# Altium schematic sheet Main.SchDoc
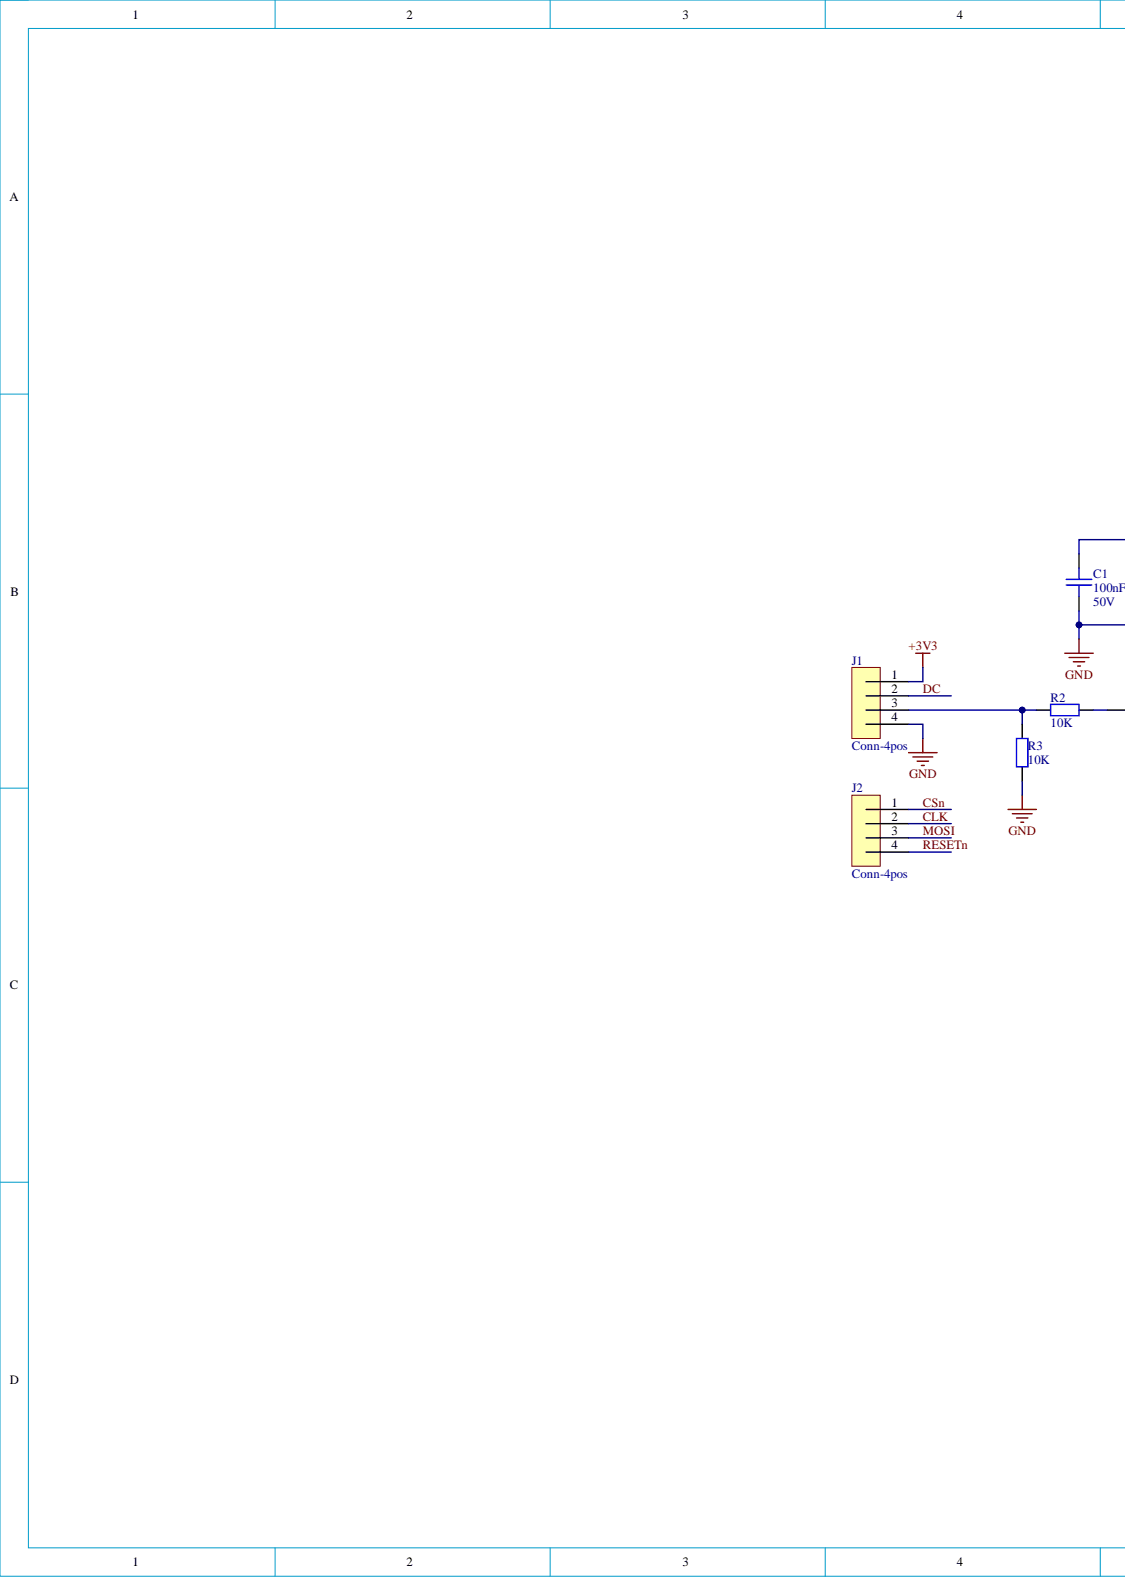
[diagram p0: Main.SchDoc, left]
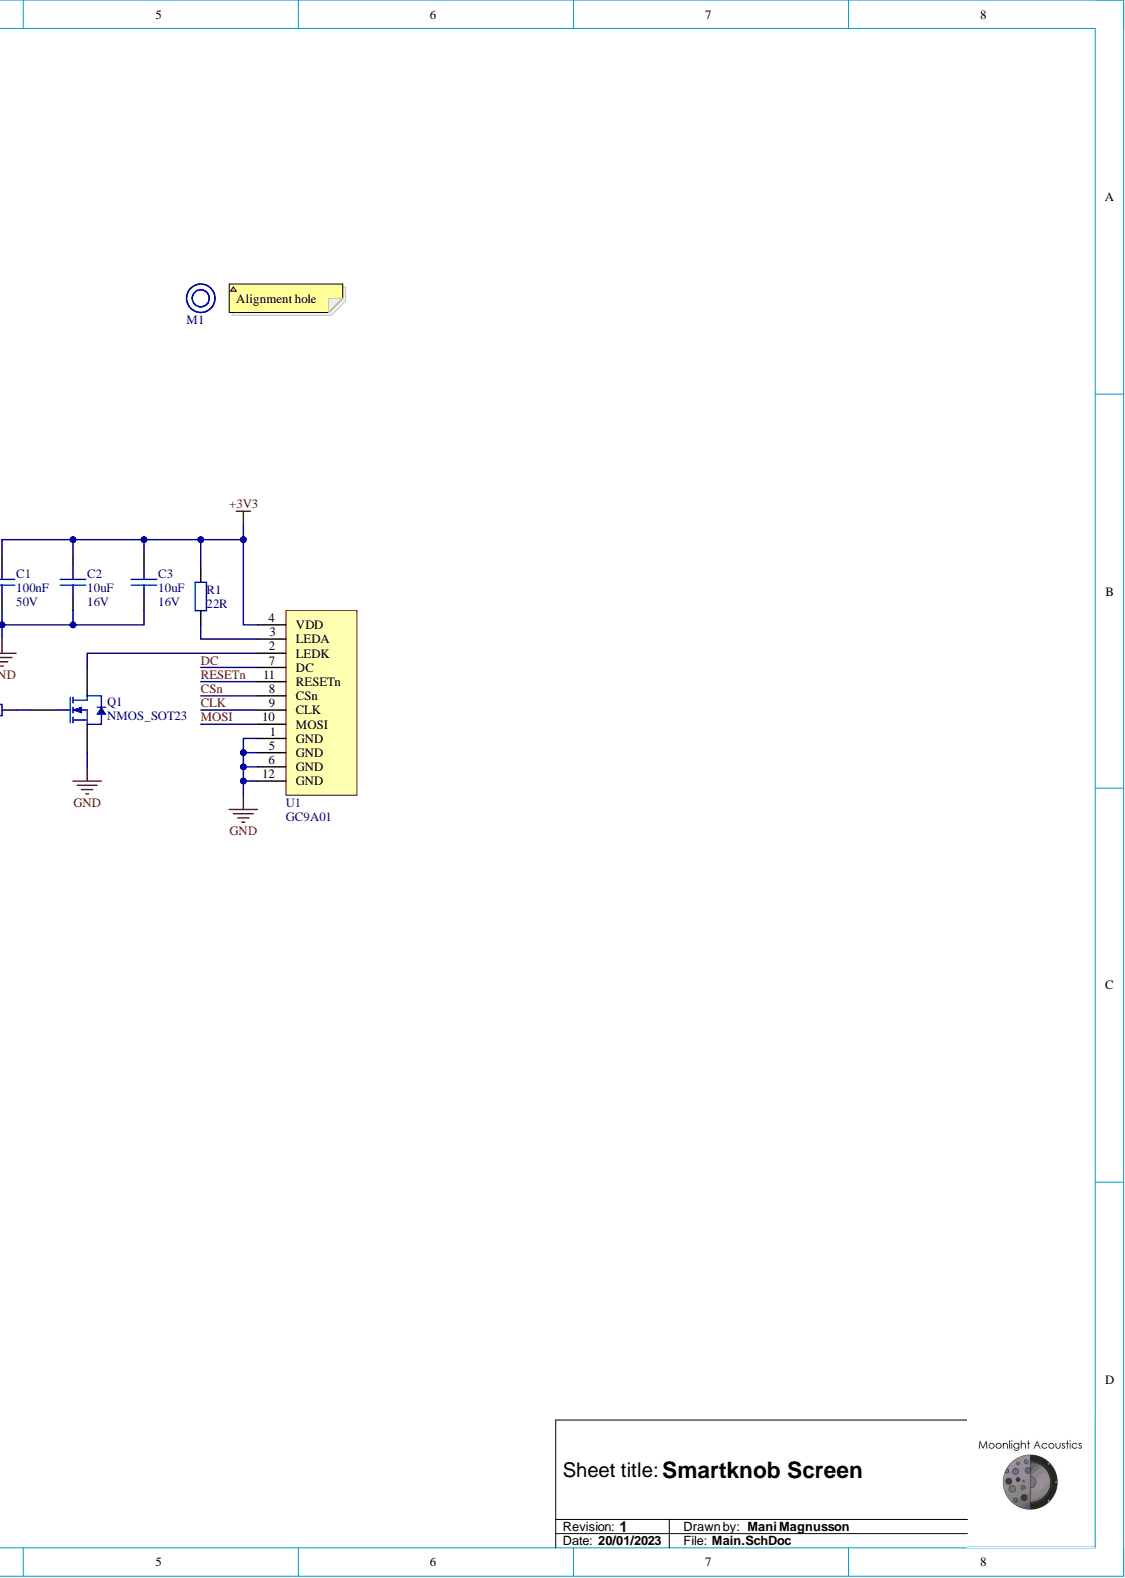
[diagram p1: Main.SchDoc, right]

## Parts
C1  value 100nF  Voltage: 50V  [C0603]  (hidden comment: CAP)
C2  value 10uF  Voltage: 16V  [C0805]  (hidden comment: CAP)
C3  value 10uF  Voltage: 16V  [C0805]  (hidden comment: CAP)
J1  Conn-4pos  [2mm_pads_4]
J2  Conn-4pos  [2mm_pads_4]
M1  Mounting_Hole_Unplated  [M1.6_Close_Unplated]  (value not drawn)
Q1  NMOS_SOT23  [SOT23]
R1  value 22R  [R0603]  (hidden comment: RES)
R2  value 10K  [R0603]  (hidden comment: RES)
R3  value 10K  [R0603]  (hidden comment: RES)
U1  GC9A01  [GC9A01]

## Nets
+3V3: C1.1 C2.1 C3.1 J1.1 R1.2 U1.4(VDD)
GND: C1.2 C2.2 C3.2 J1.4 Q1.2 R3.1 U1.1(GND) U1.5(GND) U1.6(GND) U1.12(GND)
CLK: J2.2 U1.9(CLK)
CSn: J2.1 U1.8(CSn)
DC: J1.2 U1.7(DC)
MOSI: J2.3 U1.10(MOSI)
RESETn: J2.4 U1.11(RESETn)
NetJ1_3: J1.3 R2.1 R3.2
NetQ1_1: Q1.1 R2.2
NetQ1_3: Q1.3 U1.2(LEDK)
NetR1_1: R1.1 U1.3(LEDA)

## Library data of the parts
C1 = CGA3E2X7R1H104K080AA: General purpose ceramic capacitor; Manufacturer: TDK Corporation; Database Table Name: Capacitors$; Ready for use: y; Specification: X7R, 50V, AEC-Q200 Qualified; Component Kind: Standard; Fitted: Fitted; Generic: Generic; Manufacturer Part No: CGA3E2X7R1H104K080AA; Package Ref: C0603; Part Reference: CGA3E2X7R1H104K080AA; Supplier: Digi-Key; Supplier Part No: 445-5666-1-ND; Library Name: Moonlight_Library.DbLib
C2 C3 = CGA4J1X7S1C106K125AC: General purpose ceramic capacitor; Manufacturer: TDK Corporation; Database Table Name: Capacitors$; Ready for use: y; Specification: X7S, 16V, AEC-Q200 Qualified; Component Kind: Standard; Fitted: Fitted; Generic: Generic; Manufacturer Part No: CGA4J1X7S1C106K125AC; Package Ref: C0805; Part Reference: CGA4J1X7S1C106K125AC; Supplier: Digi-Key; Supplier Part No: 445-16110-1-ND; Library Name: Moonlight_Library.DbLib
J1 J2 = 2mm_pads_4: 2mm pitch solder pads; Database Table Name: Connectors$; Ready for use: y; Component Kind: Standard; Fitted: Fitted; Generic: Generic; Manufacturer Part No: 2mm_pads_4; Package Ref: 2mm_pads_4; Part Reference: 2mm_pads_4; Library Name: Moonlight_Library.DbLib
M1 = M1.6_Close_Unplated: M1.6 Hole, Unplated; Database Table Name: Non Electrical$; Ready for use: y; Specification: Close clearance; Component Kind: Standard; Fitted: Fitted; Generic: Generic; Package Ref: M1.6_Close_Unplated; Part Reference: M1.6_Close_Unplated; Library Name: Moonlight_Library.DbLib
Q1 = BSS138: General purpose N-Channel MOSFET in SOT23 packagge; Manufacturer: onsemi; Database Table Name: Transistors FET$; Ready for use: y; Specification: Vds 50V, Id 220mA; Value: NMOS; Component Kind: Standard; Fitted: Fitted; Generic: Generic; Manufacturer Part No: BSS138; Package Ref: SOT23; Part Reference: BSS138; Supplier: Digi-Key; Supplier Part No: BSS138CT-ND; Library Name: Moonlight_Library.DbLib
R1 = CRCW060322R0JNEA: General purpose automotive thick film resistor; Manufacturer: Vishay Dale; Database Table Name: Resistors$; Ready for use: y; Specification: 5%, 200ppm, 0.1W, AEC-Q200 Qualified; Component Kind: Standard; Fitted: Fitted; Generic: Generic; Manufacturer Part No: CRCW060322R0JNEA; Package Ref: R0603; Part Reference: CRCW060322R0JNEA; Supplier: Digi-Key; Supplier Part No: 541-22GCT-ND; Library Name: Moonlight_Library.DbLib
R2 R3 = CRCW060310K0JNEA: General purpose automotive thick film resistor; Manufacturer: Vishay Dale; Database Table Name: Resistors$; Ready for use: y; Specification: 5%, 200ppm, 0.1W, AEC-Q200 Qualified; Component Kind: Standard; Fitted: Fitted; Generic: Generic; Manufacturer Part No: CRCW060310K0JNEA; Package Ref: R0603; Part Reference: CRCW060310K0JNEA; Supplier: Digi-Key; Supplier Part No: 541-10KGCT-ND; Library Name: Moonlight_Library.DbLib
U1 = GC9A01: 240x240 px round IPS display; Database Table Name: Optoelectronic$; Generic: Non Generic; Ready for use: n; Component Kind: Standard; Fitted: Fitted; Manufacturer: N/A; Manufacturer Part No: GC9A01; Package Ref: GC9A01; Part Reference: GC9A01; Supplier: N/A; Supplier Part No: N/A; Value: Display; Library Name: Moonlight_Library.DbLib
